# Altium schematic sheet 8051_ROM.SchDoc(8051_ROM)
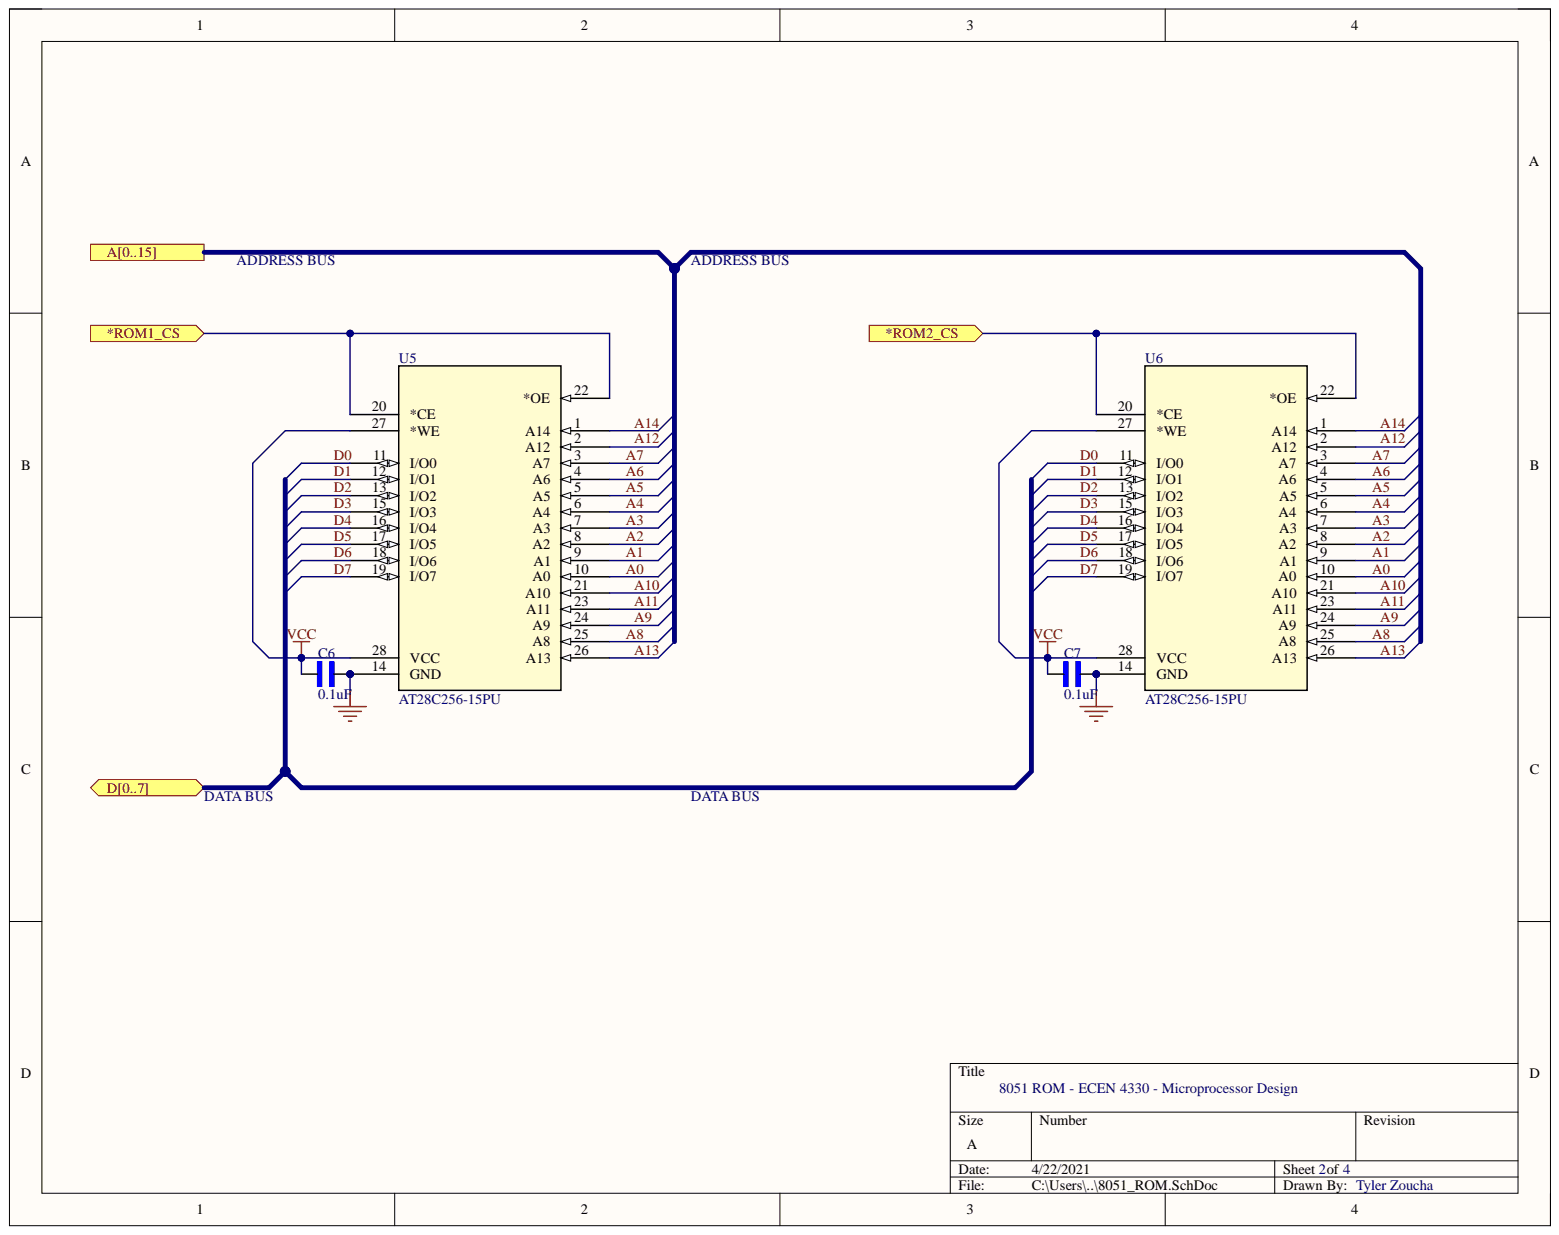
[diagram p0: 8051_ROM.SchDoc]

## Parts
C6  0.1uF  [CAP_RDER73A681K2M1H03A]
C7  0.1uF  [CAP_RDER73A681K2M1H03A]
U5  AT28C256-15PU  [28P6_ATM]
U6  AT28C256-15PU  [28P6_ATM]

## Ports
*ROM1_CS, *ROM2_CS, A0, A1, A2, A3, A4, A5, A6, A7, A8, A9, A10, A11, A12, A13, A14, A15, A[0..15], D0, D1, D2, D3, D4, D5, D6, D7, D[0..7]

## Nets
VCC: C6.1 C7.1 U5.27(*WE) U5.28(VCC) U6.27(*WE) U6.28(VCC)
*ROM1_CS: U5.20(*CE) U5.22(*OE) ; port *ROM1_CS
*ROM2_CS: U6.20(*CE) U6.22(*OE) ; port *ROM2_CS
A0: U5.10(A0) U6.10(A0) ; port A[0..15]  [also labelled ]
A1: U5.9(A1) U6.9(A1) ; port A[0..15]  [also labelled ]
A2: U5.8(A2) U6.8(A2) ; port A[0..15]  [also labelled ]
A3: U5.7(A3) U6.7(A3) ; port A[0..15]  [also labelled ]
A4: U5.6(A4) U6.6(A4) ; port A[0..15]  [also labelled ]
A5: U5.5(A5) U6.5(A5) ; port A[0..15]  [also labelled ]
A6: U5.4(A6) U6.4(A6) ; port A[0..15]  [also labelled ]
A7: U5.3(A7) U6.3(A7) ; port A[0..15]  [also labelled ]
A8: U5.25(A8) U6.25(A8) ; port A[0..15]  [also labelled ]
A9: U5.24(A9) U6.24(A9) ; port A[0..15]  [also labelled ]
A10: U5.21(A10) U6.21(A10) ; port A[0..15]  [also labelled ]
A11: U5.23(A11) U6.23(A11) ; port A[0..15]  [also labelled ]
A12: U5.2(A12) U6.2(A12) ; port A[0..15]  [also labelled ]
A13: U5.26(A13) U6.26(A13) ; port A[0..15]  [also labelled ]
A14: U5.1(A14) U6.1(A14) ; port A[0..15]  [also labelled ]
D0: U5.11(I/O0) U6.11(I/O0) ; port D[0..7]  [also labelled ]
D1: U5.12(I/O1) U6.12(I/O1) ; port D[0..7]  [also labelled ]
D2: U5.13(I/O2) U6.13(I/O2) ; port D[0..7]  [also labelled ]
D3: U5.15(I/O3) U6.15(I/O3) ; port D[0..7]  [also labelled ]
D4: U5.16(I/O4) U6.16(I/O4) ; port D[0..7]  [also labelled ]
D5: U5.17(I/O5) U6.17(I/O5) ; port D[0..7]  [also labelled ]
D6: U5.18(I/O6) U6.18(I/O6) ; port D[0..7]  [also labelled ]
D7: U5.19(I/O7) U6.19(I/O7) ; port D[0..7]  [also labelled ]
NetC6_2: C6.2 C7.2 U5.14(GND) U6.14(GND)
N00003_15: ; port A[0..15]

## Library data of the parts
C6 C7 = RDER73A681K2M1H03A: Lead capacitor X7R(EIA) with Capacitance 680pF, Rated Voltage 1000Vdc; MF: Murata; Arrow_Electronics-Purchase-URL: https://snapeda.com/shop?store=Arrow+Electronics&id=4546400; Availability: Good; Check_prices: https://www.snapeda.com/parts/RDER73A681K2M1H03A/Murata/view-part/4546400/?ref=eda; Component Kind: Standard; Library Name: leadCapacitor.SchLib; Mouser-Purchase-URL: https://snapeda.com/shop?store=Mouser&id=4546400; MP: RDER73A681K2M1H03A; Package: Radial Murata; Price: None; SnapEDA_Link: https://www.snapeda.com/parts/RDER73A681K2M1H03A/Murata/view-part/4546400/?ref=snap
U5 U6 = AT28C256-15PU: No Description Available; Built_By: EMA_UL_Team; SourceLibrary: Atmel_2010-01-01; Vendor: Atmel; Component Kind: Standard; Library Name: AT28C256.SchLib; Manufacturer_Part_Number: AT28C256-15PU; RefDes: RefDes; Type: DEV; Copyright: Copyright (C) 2018 Accelerated Designs. All rights reserved
